# Altium schematic sheet MCU.SchDoc(MCU)
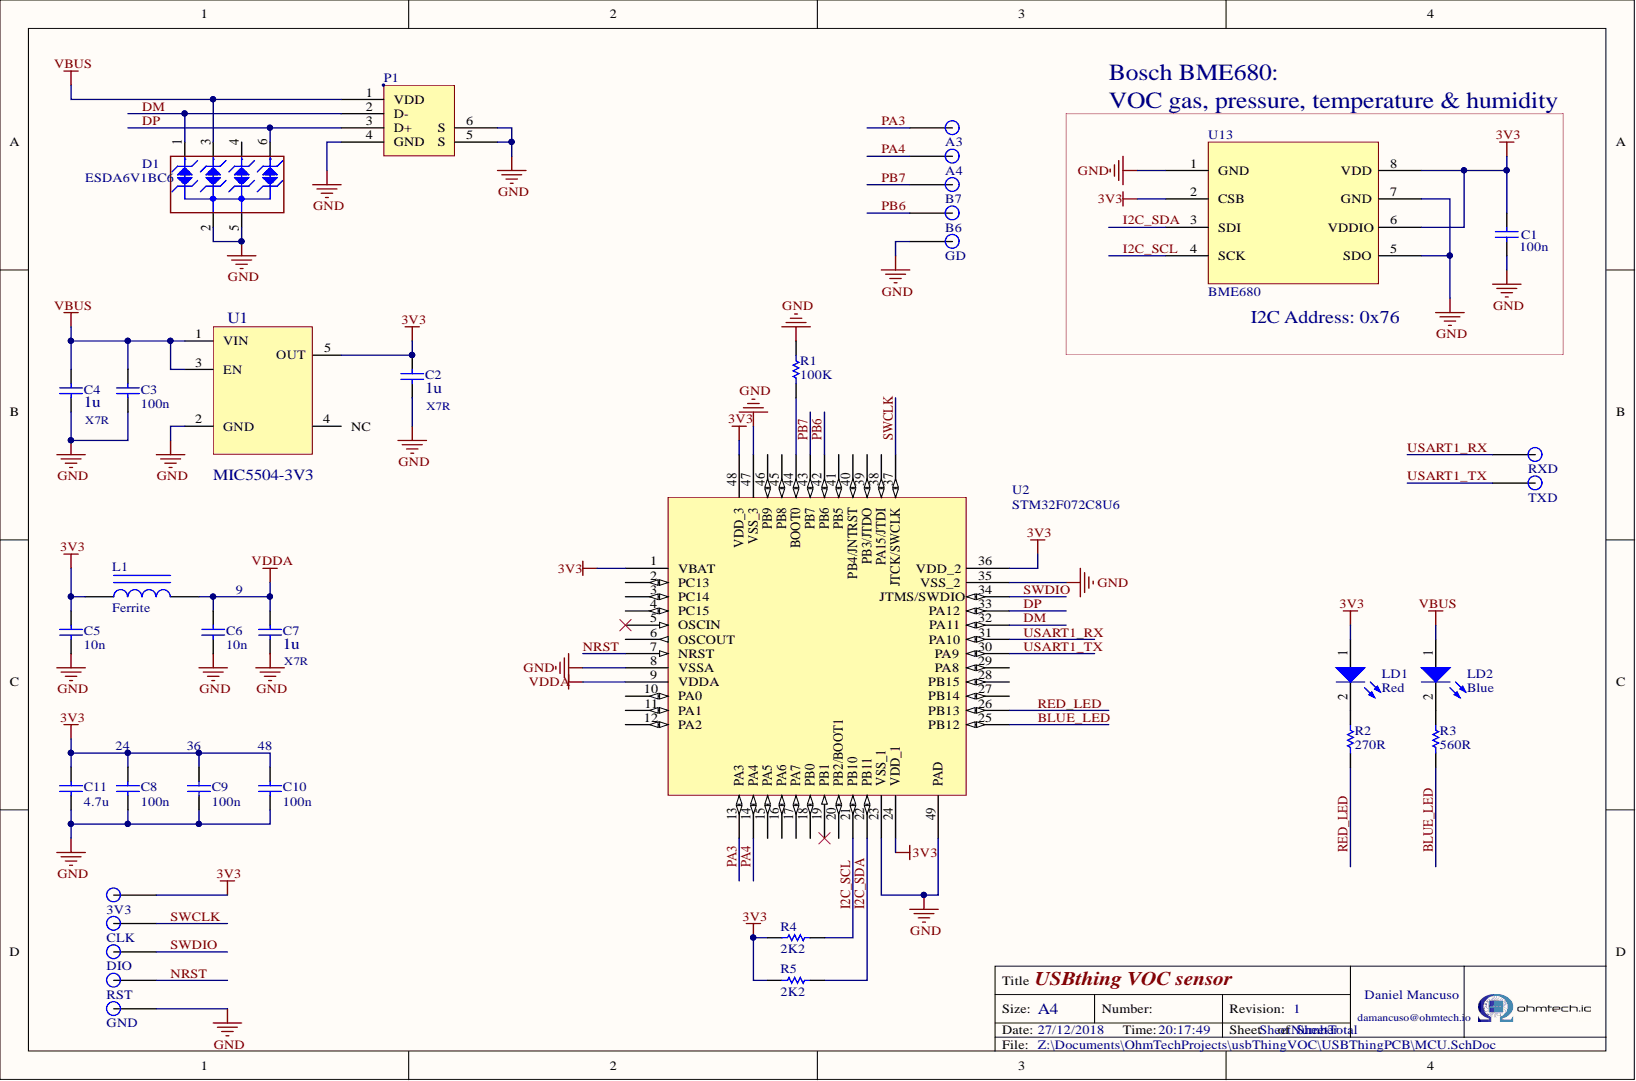
[diagram p0: MCU.SchDoc]

## Parts
3V3  Testpoint  [Testpoint]  (value not drawn)  (no BOM)
A3  Testpoint  [Testpoint]  (value not drawn)  (no BOM)
A4  Testpoint  [Testpoint]  (value not drawn)  (no BOM)
B6  Testpoint  [Testpoint]  (value not drawn)  (no BOM)
B7  Testpoint  [Testpoint]  (value not drawn)  (no BOM)
C1  value 100n  [CAP 0603/1608]  (hidden comment: Cap0603)
C2  X7R  value 1u  [CAP 0603/1608]
C3  value 100n  [CAP 0603/1608]  (hidden comment: Cap0603)
C4  X7R  value 1u  [CAP 0603/1608]
C5  value 10n  [CAP 0603/1608]  (hidden comment: Cap0603)
C6  value 10n  [CAP 0603/1608]  (hidden comment: Cap0603)
C7  X7R  value 1u  [CAP 0603/1608]
C8  value 100n  [CAP 0603/1608]  (hidden comment: Cap0603)
C9  value 100n  [CAP 0603/1608]  (hidden comment: Cap0603)
C10  value 100n  [CAP 0603/1608]  (hidden comment: Cap0603)
C11  value 4.7u  [CAP 0603/1608]  (hidden comment: Cap0603)
CLK  Testpoint  [Testpoint]  (value not drawn)  (no BOM)
D1  ESDA6V1BC6  [SOT23-6L]
DIO  Testpoint  [Testpoint]  (value not drawn)  (no BOM)
GD  Testpoint  [Testpoint]  (value not drawn)  (no BOM)
GND  Testpoint  [Testpoint]  (value not drawn)  (no BOM)
L1  Ferrite  [IND0603]
LD1  Red  [LED0603]
LD2  Blue  [LED0603]
P1  USB-A-Plug  [USB-A-plug]  (value not drawn)
R1  value 100K  [RES 0603/1608]  (hidden comment: Res0603, 0.1W)
R2  value 270R  [RES 0603/1608]  (hidden comment: Res0603, 0.1W)
R3  value 560R  [RES 0603/1608]  (hidden comment: Res0603, 0.1W)
R4  value 2K2  [RES 0603/1608]  (hidden comment: Res0603, 0.1W)
R5  value 2K2  [RES 0603/1608]  (hidden comment: Res0603, 0.1W)
RST  Testpoint  [Testpoint]  (value not drawn)  (no BOM)
RXD  Testpoint  [Testpoint]  (value not drawn)  (no BOM)
TXD  Testpoint  [Testpoint]  (value not drawn)  (no BOM)
U1  MIC5504-3V3  [SOT-23-5]
U2  STM32F072C8U6  [UFQFPN48 7X7]
U13  BME680  [BME680]

## Nets
3V3: 3V3.1 C1.2 C2.2 C5.2 C8.2 C9.2 C10.2 C11.2 L1.1 LD1.1 R4.1 R5.1 U1.5(OUT) U2.1(VBAT) U2.24(VDD_1) U2.36(VDD_2) U2.48(VDD_3) U13.2(CSB) U13.6(VDDIO) U13.8(VDD)
GND: C1.1 C2.1 C3.1 C4.1 C5.1 C6.1 C7.1 C8.1 C9.1 C10.1 C11.1 D1.2 D1.5 GD.1 GND.1 P1.4(GND) P1.5(S) P1.6(S) R1.2 U1.2(GND) U2.8(VSSA) U2.23(VSS_1) U2.35(VSS_2) U2.47(VSS_3) U2.49(PAD) U13.1(GND) U13.5(SDO) U13.7(GND)
VBUS: C3.2 C4.2 D1.3 LD2.1 P1.1(VDD) U1.1(VIN) U1.3(EN)
VDDA: C6.2 C7.2 L1.2 U2.9(VDDA)
BLUE_LED: R3.1 U2.25(PB12)
DM: D1.1 P1.2(D-) U2.32(PA11)
DP: D1.6 P1.3(D+) U2.33(PA12)
I2C_SCL: R4.2 U2.21(PB10) U13.4(SCK)
I2C_SDA: R5.2 U2.22(PB11) U13.3(SDI)
NRST: RST.1 U2.7(NRST)
PA3: A3.1 U2.13(PA3)
PA4: A4.1 U2.14(PA4)
PB6: B6.1 U2.42(PB6)
PB7: B7.1 U2.43(PB7)
RED_LED: R2.1 U2.26(PB13)
SWCLK: CLK.1 U2.37(JTCK/SWCLK)
SWDIO: DIO.1 U2.34(JTMS/SWDIO)
USART1_RX: RXD.1 U2.31(PA10)
USART1_TX: TXD.1 U2.30(PA9)
NetLD1_2: LD1.2 R2.2
NetLD2_2: LD2.2 R3.2
NetR1_1: R1.1 U2.44(BOOT0)

Not connected: D1 4; U1 4; U2 2(PC13) 3(PC14) 4(PC15) 5(OSCIN) 6(OSCOUT) 10(PA0) 11(PA1) 12(PA2) 15(PA5) 16(PA6) 17(PA7) 18(PB0) 19(PB1) 20(PB2/BOOT1) 27(PB14) 28(PB15) 29(PA8) 38(PA15/JTDI) 39(PB3/JTDO) 40(PB4/JNTRST) 41(PB5) 45(PB8) 46(PB9)

## Library data of the parts
C1-C10 = CAP_0603: -55-125C, 1608, 10V, X7R, ±10%; : DNP; Component Kind: Standard; Library Name: Passives.IntLib; Supplier 1: Digi-Key
  C1 C3 C8-C10: Supplier Part Number 1: 1276-1005-1-ND
  C2 C4 C7: Supplier Part Number 1: 1276-6524-1-ND
  C5 C6: Supplier Part Number 1: 1276-1921-1-ND
C11 = CAP_0603: 4.7u 0603; : DNP; Component Kind: Standard; Library Name: Passives.IntLib; Supplier 1: Digi-Key; Supplier Part Number 1: 1276-6684-1-ND
D1 = ESDA6V1BC6: 4-line ESD protection; Manuf_1: STM; Manuf_Part_1: ESDA5V3L; ECCN_US/EU: EAR99/NEC; Provided: ST; Ref St: 9179034; Component Kind: Standard; Distrib: ST; Library Name: Diodes.IntLib; Order: ESDA5V3L; Ordered by: STM; Supplier 1: Digi-Key; Supplier Part Number 1: 497-6635-1-ND
L1 = MMZ1608Y152BTD25: Ferrite Bead, 0603,1.5Kohm@100MHz, 300mA-max; Component Kind: Standard; LatestRevisionDate: 17-Jul-2002; LatestRevisionNote: Re-released for DXP Platform.; Library Name: Passives.IntLib; PackageDescription: Axial Device, Thru-Hole; 2 Leads; 0.9 in Pin Spacing; PackageReference: AXIAL-0.9; Published: 24-Mar-1999; Supplier 1: Digi-Key; Supplier Part Number 1: 445-172846-1-ND; Publisher: Altium Limited
LD1 = LED_RED: Typical RED, GREEN, YELLOW, AMBER GaAs LED; Component Kind: Standard; Library Name: LEDs.IntLib; Published: 8-Jun-2000; Revision: July-2002: Re-released for DXP Platform.; Supplier 1: Digi-Key; Supplier Part Number 1: 160-1447-1-ND; Publisher: Altium Limited
LD2 = LED_BLUE: Blue LED 0604; Component Kind: Standard; Library Name: LEDs.IntLib; Revision: July-2002: Re-released for DXP Platform.; Supplier 1: Digi-Key; Supplier Part Number 1: 1080-1562-1-ND
P1 = USB-A-Plug: Conn USB RCP 4 POS 2.5mm Solder RA Edge Mount 4 Terminal 1 Port; Component Kind: Standard; Library Name: Connectors.SchLib; Supplier 1: Digi-Key; Supplier Part Number 1: 102-3996-ND
R1-R5 = RES_0603: 0.10W, -55-155C, 1005, 50V, ±1%; : DNP; Component Kind: Standard; Library Name: Passives.IntLib; Supplier 1: Digi-Key
  R1: Supplier Part Number 1: RMCF0603JG100KCT-ND
  R2: Supplier Part Number 1: RMCF0603JT270RCT-ND
  R3: Supplier Part Number 1: RMCF0603JT560RCT-ND
  R4 R5: Supplier Part Number 1: RMCF0603JT2K20CT-ND
U1 = MIC5504-3V3: Linear Voltage Regulator IC Positive Fixed 1 Output 3.3V 300mA SOT-23-5; MF: Microchip; Component Kind: Standard; Library Name: Regulators.IntLib; Package: SOT23-5; Price: 0.1; Supplier 1: Digi-Key; Supplier Part Number 1: 576-4764-1-ND
U2 = STM32F072C8U6: ARM-based 32-bit MCU with 64KB Flash / 8KB ram, USB; Manuf_1: STM; Provided: ST; Ref St: 9175981; Component Kind: Standard; Distrib: ST; Library Name: Microcontrollers.SchLib; Manuf_Part_1: STM32F072C8U6; Order: STM32F072C8U6; Supplier 1: Digi-Key; Supplier Part Number 1: 497-17360-ND
U13 = BME680: Bosch Sensortec VOC, Temp, Hum and barometric sensor; Component Kind: Standard; Library Name: Sensors.SchLib; Supplier 1: Digi-Key; Supplier Part Number 1: 828-1077-6-ND
3V3 A3 A4 B6 B7 CLK DIO GD GND RST RXD TXD = Testpoint: Library Name: Mechanical.IntLib
